# Altium schematic sheet CVD01(Q2)-GS(MCU)260806CN-V2.0B2.0.SchDoc(CVD01(Q2)-GS(MCU)260806CN-V2.0B2.0)
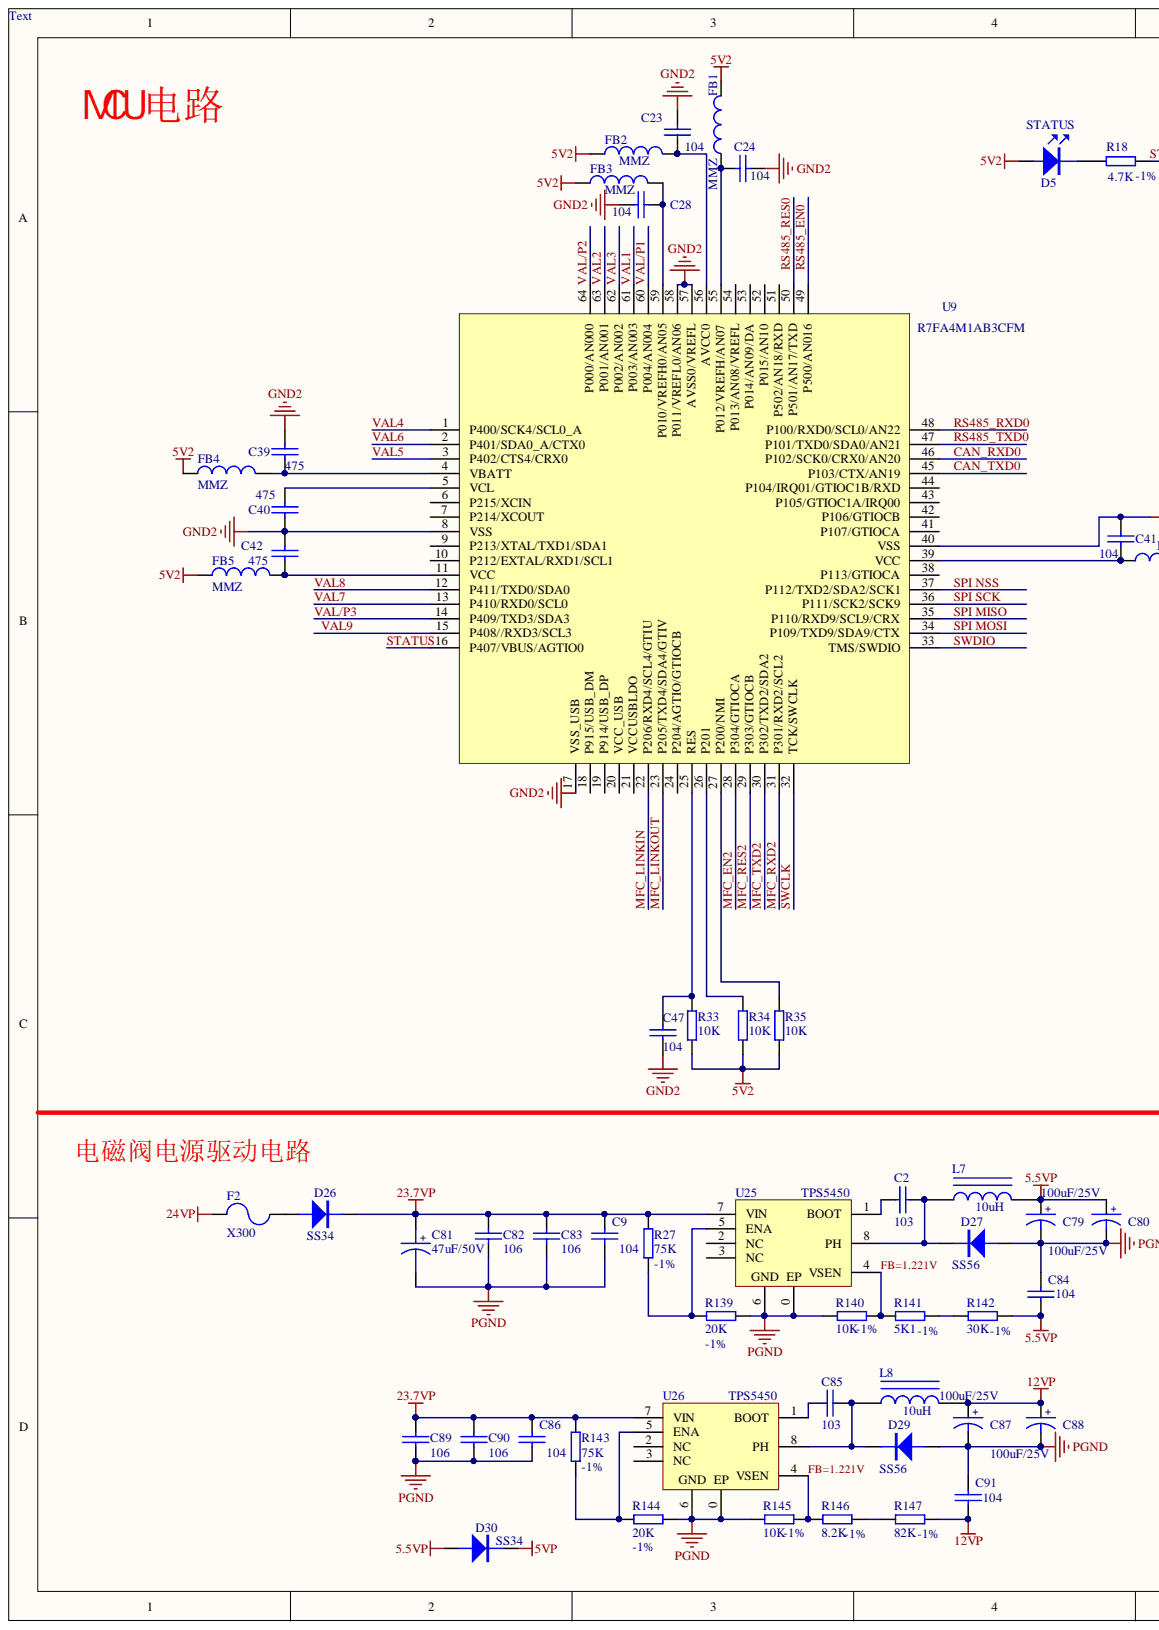
[diagram p0: CVD01, left]
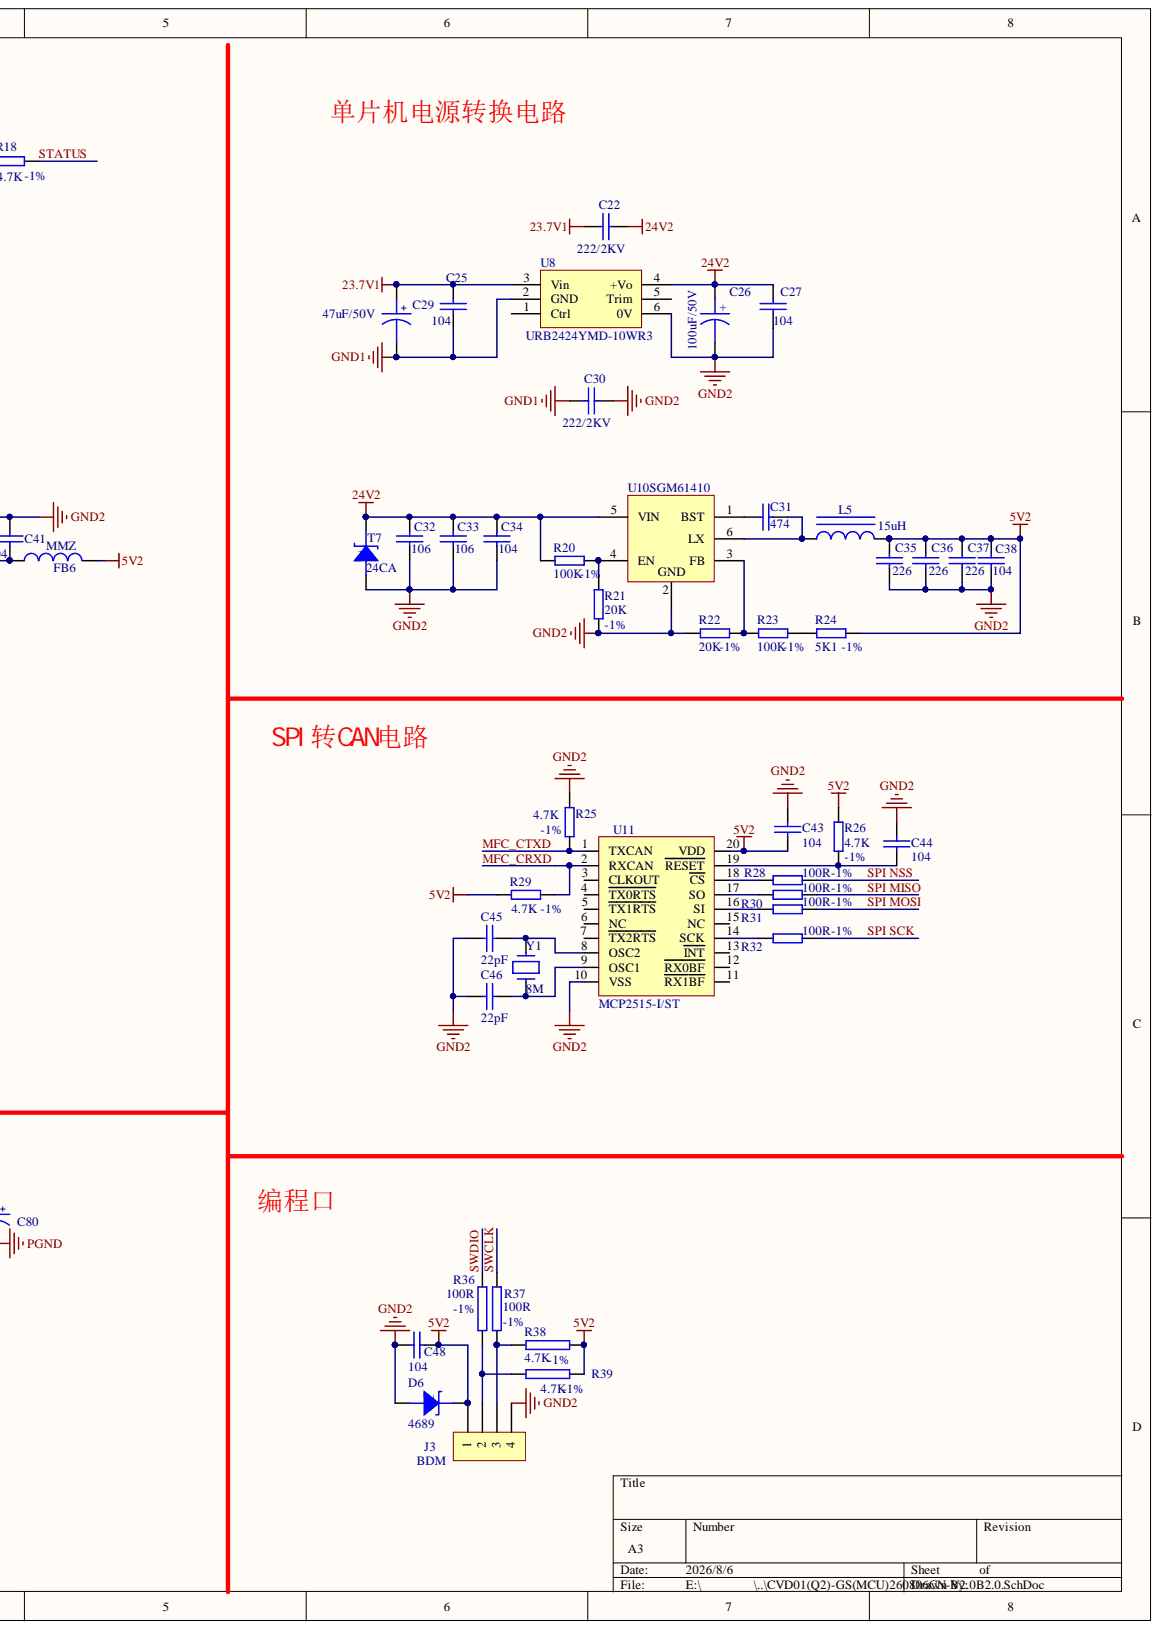
[diagram p1: CVD01, right]

## Parts
C2  103  [0603]
C9  104  [0603]
C22  222/2KV  [1206]
C23  104  [0603]
C24  104  [0603]
C25  104  [0603]
C26  100uF/50V  [8*10.5]
C27  104  [0603]
C28  104  [0603]
C29  47uF/50V  [6.3*7.7]
C30  222/2KV  [1206]
C31  474  [0603]
C32  106  [1206]
C33  106  [1206]
C34  104  [0603]
C35  226  [1206]
C36  226  [1206]
C37  226  [1206]
C38  104  [0603]
C39  475  [0603]
C40  475  [0603]
C41  104  [0603]
C42  475  [0603]
C43  104  [0603]
C44  104  [0603]
C45  22pF  [0603]
C46  22pF  [0603]
C47  104  [0603]
C48  104  [0603]
C79  100uF/25V  [6.3*7.7]
C80  100uF/25V  [6.3*7.7]
C81  47uF/50V  [6.3*7.7]
C82  106  [1206]
C83  106  [1206]
C84  104  [0603]
C85  103  [0603]
C86  104  [0603]
C87  100uF/25V  [6.3*7.7]
C88  100uF/25V  [6.3*7.7]
C89  106  [1206]
C90  106  [1206]
C91  104  [0603]
D5  STATUS  [0603(T)]
D6  4689  [SOD-123]
D26  SS34  [DO-214AC]
D27  SS56  [DO-214AC]
D29  SS56  [DO-214AC]
D30  SS34  [DO-214AC]
F2  X300  [1812]
FB1  MMZ  [0603]
FB2  MMZ  [0603]
FB3  MMZ  [0603]
FB4  MMZ  [0603]
FB5  MMZ  [0603]
FB6  MMZ  [0603]
J3  BDM  [SIP-4]
L5  15uH  [MWSA0503S-1R0MT]
L7  10uH  [IND1040]
L8  10uH  [IND1040]
R18  4.7K  ERROR: -1%  [0603]
R20  100K  ERROR: -1%  [0603]
R21  20K  ERROR: -1%  [0603]
R22  20K  ERROR: -1%  [0603]
R23  100K  ERROR: -1%  [0603]
R24  5K1  ERROR: -1%  [0603]
R25  4.7K  ERROR: -1%  [0603]
R26  4.7K  ERROR: -1%  [0603]
R27  75K  ERROR: -1%  [0603]
R28  100R  ERROR: -1%  [0603]
R29  4.7K  ERROR: -1%  [0603]
R30  100R  ERROR: -1%  [0603]
R31  100R  ERROR: -1%  [0603]
R32  100R  ERROR: -1%  [0603]
R33  10K  [0603]
R34  10K  [0603]
R35  10K  [0603]
R36  100R  ERROR: -1%  [0603]
R37  100R  ERROR: -1%  [0603]
R38  4.7K  ERROR: -1%  [0603]
R39  4.7K  ERROR: -1%  [0603]
R139  20K  ERROR: -1%  [0603]
R140  10K  ERROR: -1%  [0603]
R141  5K1  ERROR: -1%  [0603]
R142  30K  ERROR: -1%  [0603]
R143  75K  ERROR: -1%  [0603]
R144  20K  ERROR: -1%  [0603]
R145  10K  ERROR: -1%  [0603]
R146  8.2K  ERROR: -1%  [0603]
R147  82K  ERROR: -1%  [0603]
T7  24CA  [1812(T)]
U8  URB2424YMD-10WR3  [URB2415YMD-40WR3]
U9  R7FA4M1AB3CFM  [LQFP-64]
U10  SGM61410  [SOT-23-6]
U11  MCP2515-I/ST  [TSSOP-20]
U25  TPS5450  [SO-8-EP]
U26  TPS5450  [SO-8-EP]
Y1  8M  [SMD-5032_2P]

## Nets
5.5VP: C79.1 C80.1 C84.1 D30.1 L7.2 R142.2
5V2: C35.2 C36.2 C37.2 C38.1 C43.1 C48.1 D5.1 D6.2 FB1.1 FB2.2 FB3.2 FB4.1 FB5.1 FB6.2 J3.1 L5.2 R24.2 R26.2 R29.1 R33.2 R34.2 R35.2 R38.2 R39.2 U11.20(VDD)
5VP: D30.2
12VP: C87.1 C88.1 C91.1 L8.2 R147.2
23.7V1: C22.1 C25.1 C29.1 U8.3(Vin)
23.7VP: C9.1 C81.1 C82.1 C83.1 C86.1 C89.1 C90.1 D26.2 R27.2 R143.2 U25.7(VIN) U26.7(VIN)
24V2: C22.2 C26.1 C27.1 C32.1 C33.1 C34.1 R20.1 T7.2 U8.4(+Vo) U10.5(VIN)
24VP: F2.2
GND1: C25.2 C29.2 C30.1 U8.2(GND)
GND2: C23.2 C24.2 C26.2 C27.2 C28.2 C30.2 C32.2 C33.2 C34.2 C35.1 C36.1 C37.1 C38.2 C39.2 C40.2 C41.1 C42.2 C43.2 C44.2 C45.1 C46.1 C47.2 C48.2 D6.1 J3.4 R21.1 R22.1 R25.2 T7.1 U8.6(0V) U9.8(VSS) U9.17(VSS_USB) U9.40(VSS) U9.57(AVSS0/VREFL) U9.58(P011/VREFL0/AN06) U10.2 U11.10(VSS)
PGND: C9.2 C79.2 C80.2 C81.2 C82.2 C83.2 C84.2 C86.2 C87.2 C88.2 C89.2 C90.2 C91.2 D27.1 D29.1 R139.1 R140.1 R144.1 R145.1 U25.0(EP) U25.6 U26.0(EP) U26.6
CAN_RXD0: U9.46(P102/SCK0/CRX0/AN20)
CAN_TXD0: U9.45(P103/CTX/AN19)
MFC_CRXD: R29.2 U11.2(RXCAN)
MFC_CTXD: R25.1 U11.1(TXCAN)
MFC_EN2: U9.28(P304/GTIOCA)
MFC_LINKIN: U9.22(P206/RXD4/SCL4/GTIU)
MFC_LINKOUT: U9.23(P205/TXD4/SDA4/GTIV)
MFC_RES2: U9.29(P303/GTIOCB)
MFC_RXD2: U9.31(P301/RXD2/SCL2)
MFC_TXD2: U9.30(P302/TXD2/SDA2)
RS485_EN0: U9.49(P500/AN016)
RS485_RES0: U9.50(P501/AN17/TXD)
RS485_RXD0: U9.48(P100/RXD0/SCL0/AN22)
RS485_TXD0: U9.47(P101/TXD0/SDA0/AN21)
SPI MISO: R30.2 U9.35(P110/RXD9/SCL9/CRX)
SPI MOSI: R31.2 U9.34(P109/TXD9/SDA9/CTX)
SPI NSS: R28.2 U9.37(P112/TXD2/SDA2/SCK1)
SPI SCK: R32.2 U9.36(P111/SCK2/SCK9)
STATUS: R18.2 U9.16(P407/VBUS/AGTIO0)
SWCLK: R37.2 U9.32(TCK/SWCLK)
SWDIO: R36.2 U9.33(TMS/SWDIO)
VAL1: U9.61(P003/AN003)
VAL2: U9.63(P001/AN001)
VAL3: U9.62(P002/AN002)
VAL4: U9.1(P400/SCK4/SCL0_A)
VAL5: U9.3(P402/CTS4/CRX0)
VAL6: U9.2(P401/SDA0_A/CTX0)
VAL7: U9.13(P410/RXD0/SCL0)
VAL8: U9.12(P411/TXD0/SDA0)
VAL9: U9.15(P408//RXD3/SCL3)
VAL/P1: U9.60(P004/AN004)
VAL/P2: U9.64(P000/AN000)
VAL/P3: U9.14(P409/TXD3/SDA3)
NetC2_1: C2.1 U25.1(BOOT)
NetC2_2: C2.2 D27.2 L7.1 U25.8(PH)
NetC23_1: C23.1 FB2.1 U9.56(AVCC0)
NetC24_1: C24.1 FB1.2 U9.55(P012/VREFH/AN07)
NetC28_1: C28.1 FB3.1 U9.59(P010/VREFH0/AN05)
NetC31_1: C31.1 L5.1 U10.6(LX)
NetC31_2: C31.2 U10.1(BST)
NetC39_1: C39.1 FB4.2 U9.4(VBATT)
NetC40_1: C40.1 U9.5(VCL)
NetC41_2: C41.2 FB6.1 U9.39(VCC)
NetC42_1: C42.1 FB5.2 U9.11(VCC)
NetC44_1: C44.1 R26.1 U11.19(RESET)
NetC45_2: C45.2 U11.8(OSC2) Y1.2
NetC46_2: C46.2 U11.9(OSC1) Y1.1
NetC47_1: C47.1 R33.1 U9.25(RES)
NetC85_1: C85.1 U26.1(BOOT)
NetC85_2: C85.2 D29.2 L8.1 U26.8(PH)
NetD5_2: D5.2 R18.1
NetD26_1: D26.1 F2.1
NetJ3_2: J3.2 R36.1 R39.1
NetJ3_3: J3.3 R37.1 R38.1
NetR20_2: R20.2 R21.2 U10.4(EN)
NetR22_2: R22.2 R23.1 U10.3(FB)
NetR23_2: R23.2 R24.1
NetR27_1: R27.1 R139.2 U25.5(ENA)
NetR28_1: R28.1 U11.18(CS)
NetR30_1: R30.1 U11.17(SO)
NetR31_1: R31.1 U11.16(SI)
NetR32_1: R32.1 U11.14(SCK)
NetR34_1: R34.1 U9.26(P201)
NetR35_1: R35.1 U9.27(P200/NMI)
NetR140_2: R140.2 R141.1 U25.4(VSEN)
NetR141_2: R141.2 R142.1
NetR143_1: R143.1 R144.2 U26.5(ENA)
NetR145_2: R145.2 R146.1 U26.4(VSEN)
NetR146_2: R146.2 R147.1

Not connected: U8 1(Ctrl) 5(Trim); U9 6(P215/XCIN) 7(P214/XCOUT) 9(P213/XTAL/TXD1/SDA1) 10(P212/EXTAL/RXD1/SCL1) 18(P915/USB_DM) 19(P914/USB_DP) 20(VCC_USB) 21(VCCUSBLDO) 24(P204/AGTIO/GTIOCB) 38(P113/GTIOCA) 41(P107/GTIOCA) 42(P106/GTIOCB) 43(P105/GTIOC1A/IRQ00) 44(P104/IRQ01/GTIOC1B/RXD) 51(P502/AN18/RXD) 52(P015/AN10) 53(P014/AN09/DA) 54(P013/AN08/VREFL); U11 3(CLKOUT) 4(TX0RTS) 5(TX1RTS) 6(NC) 7(TX2RTS) 11(RX1BF) 12(RX0BF) 13(INT) 15(NC); U25 2(NC) 3(NC); U26 2(NC) 3(NC)

## Library data of the parts
C2 C85 = CAP: Capacitor; NAME: 贴片电容; MODEL: 0603-103; Library Name: Sch.SchLib; Component Kind: Standard
C9 C84 C86 C91 = CAP: Capacitor; NAME: 贴片电容; MODEL: 0603-104; Library Name: Sch.SchLib; Component Kind: Standard
C22 C30 = CAP: 无极性电容; NAME: 贴片电容; MODEL: 1206-222/2KV; PRICE: 0.23; Component Kind: Standard; Library Name: ChangAi-Library-4.00-200110-ZC.IntLib
C23-C25 C27 C28 C34 C38 C41 C43 C44 C47 C48 = CAP: 无极性电容; NAME: 贴片电容; MODEL: 0603-104; Component Kind: Standard
  C23 C24 C28 C41 C47: Library Name: ChangAi-Library-2.00-181019-ZZM.SchLib
  C34 C38: Library Name: ChangAi-Library-3.00-191203-ZC.SchLib
  C43 C44: Library Name: ChangAi-Library-1.00-231007-TJ.SchLib
  C48: Library Name: ChangAi-Library-4.00-200110-ZC.IntLib
C26 = CAPACITOR POL: NAME: 贴片电解电容; PRICE: 0.37; MODEL: 100uF/50V; Component Kind: Standard
C29 C81 = CAP+: 电解电容; NAME: 贴片电解电容; PRICE: 0.153; MODEL: 47uF/50V; Component Kind: Standard; Library Name: ChangAi-Library-2.00-181023-ZZM.IntLib
C31 = CAP: 无极性电容; NAME: 贴片电容; MODEL: 0603-474; Component Kind: Standard; Library Name: ChangAi-Library-3.00-191203-ZC.SchLib
C32 C33 C82 C83 C89 C90 = CAP: 无极性电容; NAME: 贴片电容; MODEL: 1206-106; Component Kind: Standard
  C32 C33: Library Name: ChangAi-Library-3.00-191203-ZC.SchLib
  C82 C83 C89 C90: Library Name: ChangAi-Library-4.00-200110-ZC.IntLib
C35-C37 = CAP: Capacitor; NAME: 贴片电容; MODEL: 1206-226; Component Kind: Standard
C39 C40 C42 = CAP: 无极性电容; NAME: 贴片电容; MODEL: 0603-475; Component Kind: Standard; Library Name: ChangAi-Library-2.00-181019-ZZM.SchLib
C45 C46 = CAP: 无极性电容; NAME: 贴片电容; MODEL: 0603-22pF; Component Kind: Standard; Library Name: ChangAi-Library-1.00-231007-TJ.SchLib
C79 C80 C87 C88 = CAP+: NAME: 贴片电解电容; MODEL: 100uF/25V; Component Kind: Standard; Library Name: ChangAi-Library-4.00-200110-ZC.SchLib
D5 = LED: 发光二极管; NAME: 贴片发光二极管; MODEL: NCD0603R1红色; PRICE: 0.028; Component Kind: Standard; Library Name: ChangAi-Library-4.00-200110-ZC.IntLib
D6 = ZENER: TVS管; NAME: 齐纳二极管; MODEL: MMSZ4689-HE3-18; PRICE: 0.08; Component Kind: Standard; Library Name: ChangAi-Library-4.00-200110-ZC.IntLib
D26 D30 = DIODE: 贴片二极管; MODEL: 1N5822(SS34); PRICE: 0.18; NAME: 贴片二极管; Component Kind: Standard
  D26: Library Name: ChangAi-Library-3.00-191203-ZC.SchLib
  D30: Library Name: ChangAi-Library-4.00-200108-ZC.SchLib
D27 D29 = DIODE: 贴片二极管; NAME: 贴片二极管; MODEL: SS56; Component Kind: Standard; Library Name: ChangAi-Library-4.00-200110-ZC.IntLib
F2 = FUSE: 自恢复保险管; MODEL: 1812X300 (SMD1812P300SLR/24); PRICE: 0.45; NAME: 自恢复保险管; Component Kind: Standard; Library Name: ChangAi-Library-2.00-181023-ZZM.IntLib
FB1-FB3 = INDUCTOR: 磁珠; NAME: 贴片磁珠; MODEL: MMZ1608R102AT000; Component Kind: Standard; Library Name: ChangAi-Library-3.00-191203-ZC.SchLib
FB4-FB6 = INDUCTOR: 磁珠; NAME: 贴片磁珠; MODEL: MMZ2012R102AT000; Component Kind: Standard; Library Name: ChangAi-Library-3.00-191203-ZC.SchLib
J3 = CON4: 排母; NAME: 单排插针座; MODEL: 1*4P; Component Kind: Standard; Library Name: ChangAi-Library-2.00-181023-ZZM.SchLib
L5 = INDUCTOR1: 功率电感; NAME: 贴片电感; MODEL: MWSA0503S-150MT; PRICE: 0.23; Component Kind: Standard; Library Name: ChangAi-Library-2.00-181019-ZZM.SchLib
L7 L8 = INDUCTOR1: 线绕磁环电感; NAME: 贴片电感; MODEL: IND1040-10uH; Component Kind: Standard; Library Name: Previous Backup of Sch.Lib
R18 = RES: 1%精度; NAME: 贴片电阻; MODEL: 0603-4K7; Component Kind: Standard; Library Name: ChangAi-Library-4.00-200110-ZC.IntLib
R20 R23 = RES: 1%精度; NAME: 贴片电阻; MODEL: 0603-100K; Component Kind: Standard; Library Name: ChangAi-Library-3.00-191203-ZC.SchLib
R21 R22 R139 R144 = RES: 1%精度; NAME: 贴片电阻; MODEL: 0603-20K; Component Kind: Standard; Library Name: ChangAi-Library-3.00-191203-ZC.SchLib
R24 R141 = RES: 1%精度; NAME: 贴片电阻; MODEL: 0603-5K1; Component Kind: Standard; Library Name: ChangAi-Library-3.00-191203-ZC.SchLib
R25 R26 R29 = RES: 1%精度; NAME: 贴片电阻; MODEL: 0603-4.7K; Component Kind: Standard; Library Name: ChangAi-Library-4.00-200110-ZC.IntLib
R27 R143 = RES: 1%精度; NAME: 贴片电阻; MODEL: 0603-75K; Component Kind: Standard; Library Name: ChangAi-Library-3.00-191203-ZC.SchLib
R28 R30-R32 = RES: 1%精度; NAME: 贴片电阻; MODEL: 0603-100R; Component Kind: Standard; Library Name: ChangAi-Library-4.00-200110-ZC.IntLib
R33-R35 = RES: 1%精度; NAME: 贴片电阻; MODEL: 0603-10K; ERROR: -1%; Component Kind: Standard; Library Name: ChangAi-Library-5.04-200818-LP.SchLib
R36 R37 = RES1: 1%精度; NAME: 贴片电阻; MODEL: 0603-100R; Component Kind: Standard
R38 R39 = RES1: 1%精度; NAME: 贴片电阻; MODEL: 0603-4.7K; Component Kind: Standard
R140 R145 = RES: 1%精度; NAME: 贴片电阻; MODEL: 0603-10K; Component Kind: Standard; Library Name: ChangAi-Library-3.00-191203-ZC.SchLib
R142 = RES: 1%精度; NAME: 贴片电阻; MODEL: 0603-30K; Component Kind: Standard; Library Name: ChangAi-Library-3.00-191203-ZC.SchLib
R146 = RES: 1%精度; NAME: 贴片电阻; MODEL: 0603-8.2K; Component Kind: Standard; Library Name: ChangAi-Library-3.00-191203-ZC.SchLib
R147 = RES: 1%精度; NAME: 贴片电阻; MODEL: 0603-82K; Component Kind: Standard; Library Name: ChangAi-Library-3.00-191203-ZC.SchLib
T7 = ZENER: TVS管; MODEL: LM1K24CA; PRICE: 0.45; NAME: TVS管; Component Kind: Standard; Library Name: ChangAi-Library-4.00-200110-ZC.SchLib
U8 = URB2415YMD-40WR3: NAME: DC/DC电源模块; PRICE: 88; MODEL: URB2424YMD-10WR3; Component Kind: Standard; Library Name: ChangAi-Library-5.05-201209-LP.~(33).SchLib
U9 = R7FA4M1AB3CFM: 单片机; NAME: 贴片集成块; MODEL: R7FA4M1AB3CFM; Component Kind: Standard; Library Name: ChangAi-Library-3.00-191203-ZC.SchLib
U10 = SY8209ABC: NAME: 贴片集成块; MODEL: SGM61410XN6G/TR; PRICE: 0.73; Component Kind: Standard; Library Name: ChangAi-Library-5.04-200818-LP.SchLib
U11 = MCP2515-I: CAN芯片; NAME: 贴片集成块; MODEL: MCP2515-I/ST; Component Kind: Standard; Library Name: ChangAi-Library-1.00-231007-TJ.SchLib
U25 U26 = TPS5450DDA: DC-DC降压; 库房编号: 013400004041; NAME: 贴片集成块; MODEL: TPS5450DDA; Component Kind: Standard; Library Name: ChangAi-Library-4.00-200110-ZC.IntLib
Y1 = 8M: 晶振; NAME: 贴片晶振; MODEL: NX5032CA-8MHZ; Component Kind: Standard; Library Name: ChangAi-Library-1.00-231007-TJ.SchLib
